# Altium schematic sheet CW308_Clock.SchDoc(CW308_Clock)
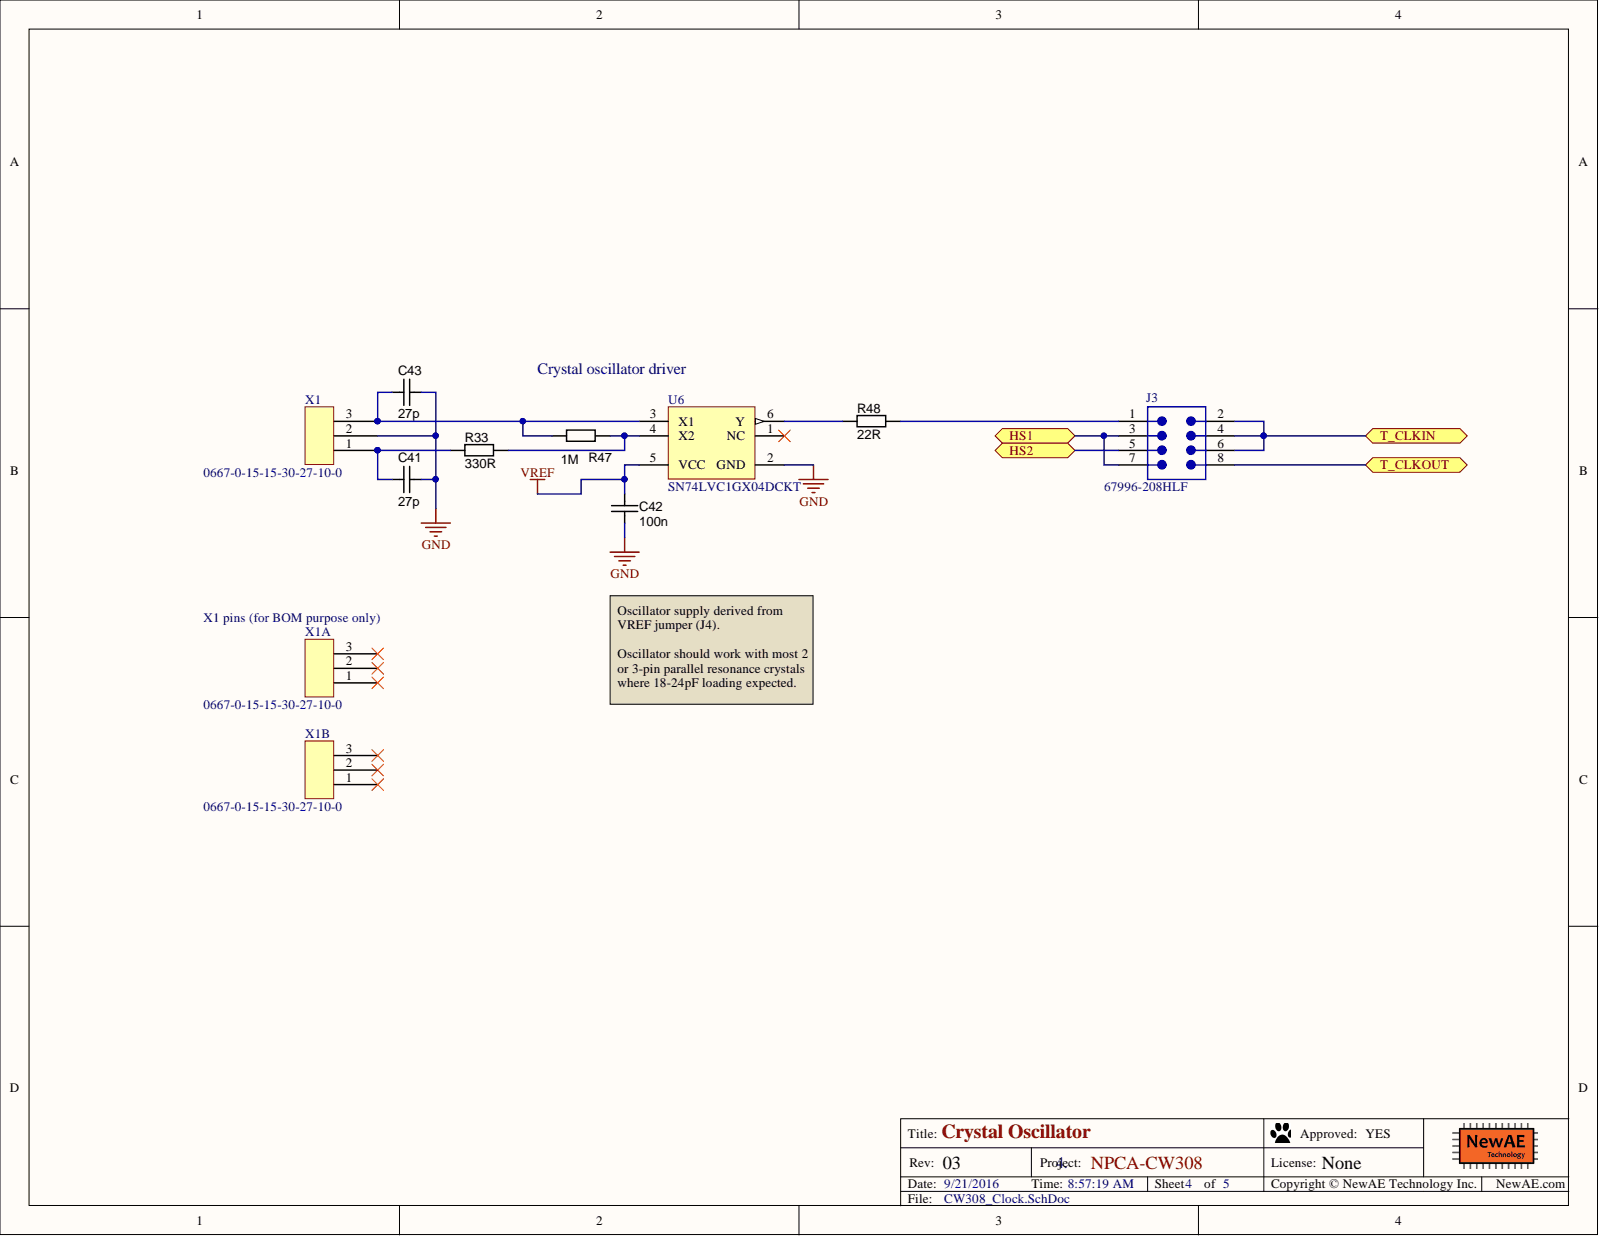
[diagram p0: CW308_Clock.SchDoc]

## Parts
C41  27p  [CAPC1608X90N]
C42  value 100n  [CAPC1608X90N]  (hidden comment: approved sub OK)
C43  27p  [CAPC1608X90N]
J3  67996-208HLF  [SMTC-MTSW-104-12-X-D-006]
R33  value 330R  [RESC1608X55N]  (hidden comment: Thick Film)
R47  value 1M  [RESC1608X55N]  (hidden comment: Thick Film)
R48  value 22R  [RESC1608X55N]  (hidden comment: Thick Film)
U6  SN74LVC1GX04DCKT  [DCK0006A_L]
X1  0667-0-15-15-30-27-10-0  [HC49U_SOCKET]
X1A  0667-0-15-15-30-27-10-0  [HC49U_SOCKET]  (mechanical)
X1B  0667-0-15-15-30-27-10-0  [HC49U_SOCKET]  (mechanical)

## Ports
HS1, HS2, T_CLKIN, T_CLKOUT

## Nets
GND: C41.2 C42.2 C43.2 U6.2(GND) X1.2
VREF: C42.1 U6.5(VCC)
HS1: J3.3 J3.7 ; port HS1
HS2: J3.5 ; port HS2
T_CLKIN: J3.2 J3.4 J3.6 ; port T_CLKIN
T_CLKOUT: J3.8 ; port T_CLKOUT
NetC41_1: C41.1 R33.1 X1.1
NetC43_1: C43.1 R47.1 U6.3(X1) X1.3
NetJ3_1: J3.1 R48.2
NetR33_2: R33.2 R47.2 U6.4(X2)
NetR48_1: R48.1 U6.6(Y)

Not connected: U6 1(NC); X1A 1 2 3; X1B 1 2 3

## Library data of the parts
C41 C43 = CAPC_27p_0603_NP0_100V_1%: Capacitor SMD Cer 27pF 1% 100V NP0 0603; Manufacturer 1: AVX Corporation; Manufacturer Part Number 1: 06031A270FAT2A; Ratings: 100V; NP0; SCDType: undef; Component Kind: Standard; Library Name: PBL - Capacitors.SCHLIB; Operating Temperature: -55°C ~ 125°C; Package / Case: 0603 (1608 Metric); Supplier 1: Digi-Key; Supplier Part Number 1: 478-3681-1-ND; Tolerance: ±1%; Value: 27p
C42 = CAPC_100n_0603_X7R_50V_10%: Capacitor SMD Cer 100nF 10% 50V X7R 0603; Manufacturer 1: AVX Corporation; Manufacturer Part Number 1: 06035C104KAT2A; Material: ceramic; Ratings: 50V; X7R; SCDType: spec; Component Kind: Standard; ComponentLink1Description: http://www.avx.com/docs/Catalogs/cx7r.pdf; ComponentLink1URL: http://www.avx.com/docs/Catalogs/cx7r.pdf; ComponentLink2Description: http://product.tdk.com/capacitor/mlcc/en/documents/mlcc_commercial_general_en.pdf; ComponentLink2URL: http://product.tdk.com/capacitor/mlcc/en/documents/mlcc_commercial_general_en.pdf; Library Name: PBL - Capacitors.SCHLIB; Manufacturer 2: TDK Corporation; Manufacturer Part Number 2: C1608X7R1H104K; Package / Case: 0603 (1608 Metric); Supplier 1: Digi-Key; Supplier 2: Digi-Key; Supplier Part Number 1: 478-5052-1-ND; Supplier Part Number 2: 445-1314-1-ND; Tolerance: ±10%
J3 = CMP-1024-00110-1: Manufacturer: Amphenol FCI; Pitch: 2.54 mm; Component Kind: Standard; Library Name: Altium Content Vault; Mounting Technology: Through-hole; Orientation: Vertical; PartNumber: 67996-208HLF; RoHS: True; Supplier 1: Digi-Key; Supplier Part Number 1: 609-3211-ND; Temperature Range: -55 to 105 degC
R33 = RES_330R_0603_5%: Resistor SMD chip 330 Ohm 0.1W 5% 0603; Manufacturer 1: Vishay Dale; Manufacturer Part Number 1: CRCW0603330RJNEA; Ratings: 1/10 W; SCDType: spec; Component Kind: Standard; ComponentLink1Description: http://www.vishay.com/doc?20035; ComponentLink1URL: http://www.vishay.com/doc?20035; ComponentLink2Description: http://www.yageo.com/documents/recent/PYu-RC0603_51_RoHS_L_4.pdf; ComponentLink2URL: http://www.yageo.com/documents/recent/PYu-RC0603_51_RoHS_L_4.pdf; Library Name: PBL - Resistors.SCHLIB; Manufacturer 2: Yageo; Manufacturer Part Number 2: RC0603JR-07330RL; Material: Thick Film; Package / Case: 0603 (1608 metric); Supplier 1: Digi-Key; Supplier 2: Digi-Key; Supplier Part Number 1: 541-330GCT-ND; Supplier Part Number 2: 311-330GRCT-ND; Tolerance: ±5%
R47 = RES_1M_0603_5%: Resistor SMD chip 1M Ohm 0.1W 5% 0603; Manufacturer 1: Vishay Dale; Manufacturer Part Number 1: CRCW06031M00JNEA; Ratings: 1/10 W; SCDType: spec; Component Kind: Standard; ComponentLink1Description: http://www.vishay.com/doc?20008; ComponentLink1URL: http://www.vishay.com/doc?20008; ComponentLink2Description: http://www.yageo.com/documents/recent/PYu-RC0603_51_RoHS_L_4.pdf; ComponentLink2URL: http://www.yageo.com/documents/recent/PYu-RC0603_51_RoHS_L_4.pdf; Library Name: PBL - Resistors.SCHLIB; Manufacturer 2: Yageo; Manufacturer Part Number 2: RC0603JR-071ML; Material: Thick Film; Package / Case: 0603 (1608 metric); Supplier 1: Digi-Key; Supplier 2: Digi-Key; Supplier Part Number 1: 541-1.0MGCT-ND; Supplier Part Number 2: 311-1.0MGRCT-ND; Tolerance: ±5%
R48 = RES_22R_0603_5%: Resistor SMD chip 22 Ohm 0.1W 5% 0603; Manufacturer 1: Yageo; Manufacturer Part Number 1: RC0603JR-0722RL; Ratings: 1/10W; SCDType: spec; Temperature Coefficient: ±100ppm/°C; Case: 0603 (1608 metric); Component Kind: Standard; ComponentLink1Description: http://www.yageo.com/documents/recent/PYu-RC0603_51_RoHS_L_4.pdf; ComponentLink1URL: http://www.yageo.com/documents/recent/PYu-RC0603_51_RoHS_L_4.pdf; ComponentLink2Description: http://www.samsungsem.com/servlet/FileDownload?filename=productcatalog/pdf/Chip_Resistor.pdf; ComponentLink2URL: http://www.samsungsem.com/servlet/FileDownload?filename=productcatalog/pdf/Chip_Resistor.pdf; ComponentLink3Description: http://www.seielect.com/Catalog/SEI-RMCF_RMCP.pdf; ComponentLink3URL: http://www.seielect.com/Catalog/SEI-RMCF_RMCP.pdf; ComponentLink4Description: http://rohmfs.rohm.com/en/products/databook/datasheet/passive/resistor/chip_resistor/mcr_g.pdf; ComponentLink4URL: http://rohmfs.rohm.com/en/products/databook/datasheet/passive/resistor/chip_resistor/mcr_g.pdf; ComponentLink5Description: http://industrial.panasonic.com/www-cgi/jvcr13pz.cgi?E+PZ+3+AOA0001+ERJ3GEYJ220V+7+WW; ComponentLink5URL: http://industrial.panasonic.com/www-cgi/jvcr13pz.cgi?E+PZ+3+AOA0001+ERJ3GEYJ220V+7+WW; Library Name: PBL - Resistors.SCHLIB; Manufacturer 2: Samsung Electro-Mechanics America, Inc; Manufacturer 3: Stackpole Electronics Inc; Manufacturer 4: Rohm Semiconductor; Manufacturer 5: Panasonic Electronic Components; Manufacturer Part Number 2: RC1608J220CS; Manufacturer Part Number 3: RMCF0603JT22R0; Manufacturer Part Number 4: MCR03ERTJ220; Manufacturer Part Number 5: ERJ-3GEYJ220V; Package / Case: 0603 (1608 metric); RoHS 1: RoHS Compliant; RoHS 2: RoHS Compliant; RoHS 3: RoHS Compliant; RoHS 4: RoHS Compliant; RoHS 5: RoHS Compliant; Supplier 1: Digi-Key; Supplier 2: Digi-Key; Supplier 3: Digi-Key; Supplier 4: Digi-Key; Supplier 5: Digi-Key; Supplier Part Number 1: 311-22GRCT-ND; Supplier Part Number 2: 1276-5022-6-ND; Supplier Part Number 3: RMCF0603JT22R0DKR-ND; Supplier Part Number 4: RHM22CGDKR-ND; Supplier Part Number 5: P22GDKR-ND; Tolerance: ±5%
U6 = CMP-0859-00485-3: Crystal Oscillator Driver, DCK0006A, SMALL T&R; Manufacturer: Texas Instruments; 3-State Output: No; Bits(#): 1; F @ Nom Voltage(Max)(Mhz): 150; ICC @ Nom Voltage(Max)(mA): 0.01; Input Type: CMOS/TTL; Logic: True; Output Drive (IOL/IOH)(Max)(mA): 32/-32; Output Type: CMOS; Rating: Catalog; Sub-Family: Inverting Buffer/Driver; Technology Family: LVC; tpd @ Nom Voltage(Max)(ns): 7,4,3.7,7; VCC(Max)(V): 5.5; VCC(Min)(V): 1.65; Voltage(Nom)(V): 1.8,2.5,3.3,5; Component Kind: Standard; DatasheetVersion: SCES581C; Generic Part Number: SN74LVC1GX04; Library Name: Altium Content Vault; Operating Temperature Range(C): -40 to 125; PackageReference: DCK0006A; Schmitt Trigger: No; Supplier 1: Digi-Key; Supplier Part Number 1: 296-32316-1-ND
X1 X1A X1B = XTALHOLDER_49US: XTAL Holder built using 3x 0667-0-15-15-30-27-10-0; Library Name: NewAE_AltiumLib.SchLib; Manufacture Part Number 1: 3x 0667-0-15-15-30-27-10-0; Supplier 1: Digi-Key; Supplier Part Number 1: ED90102-ND
  X1: Component Kind: Standard
